# Altium schematic sheet Sheet3.SchDoc(Sheet3)
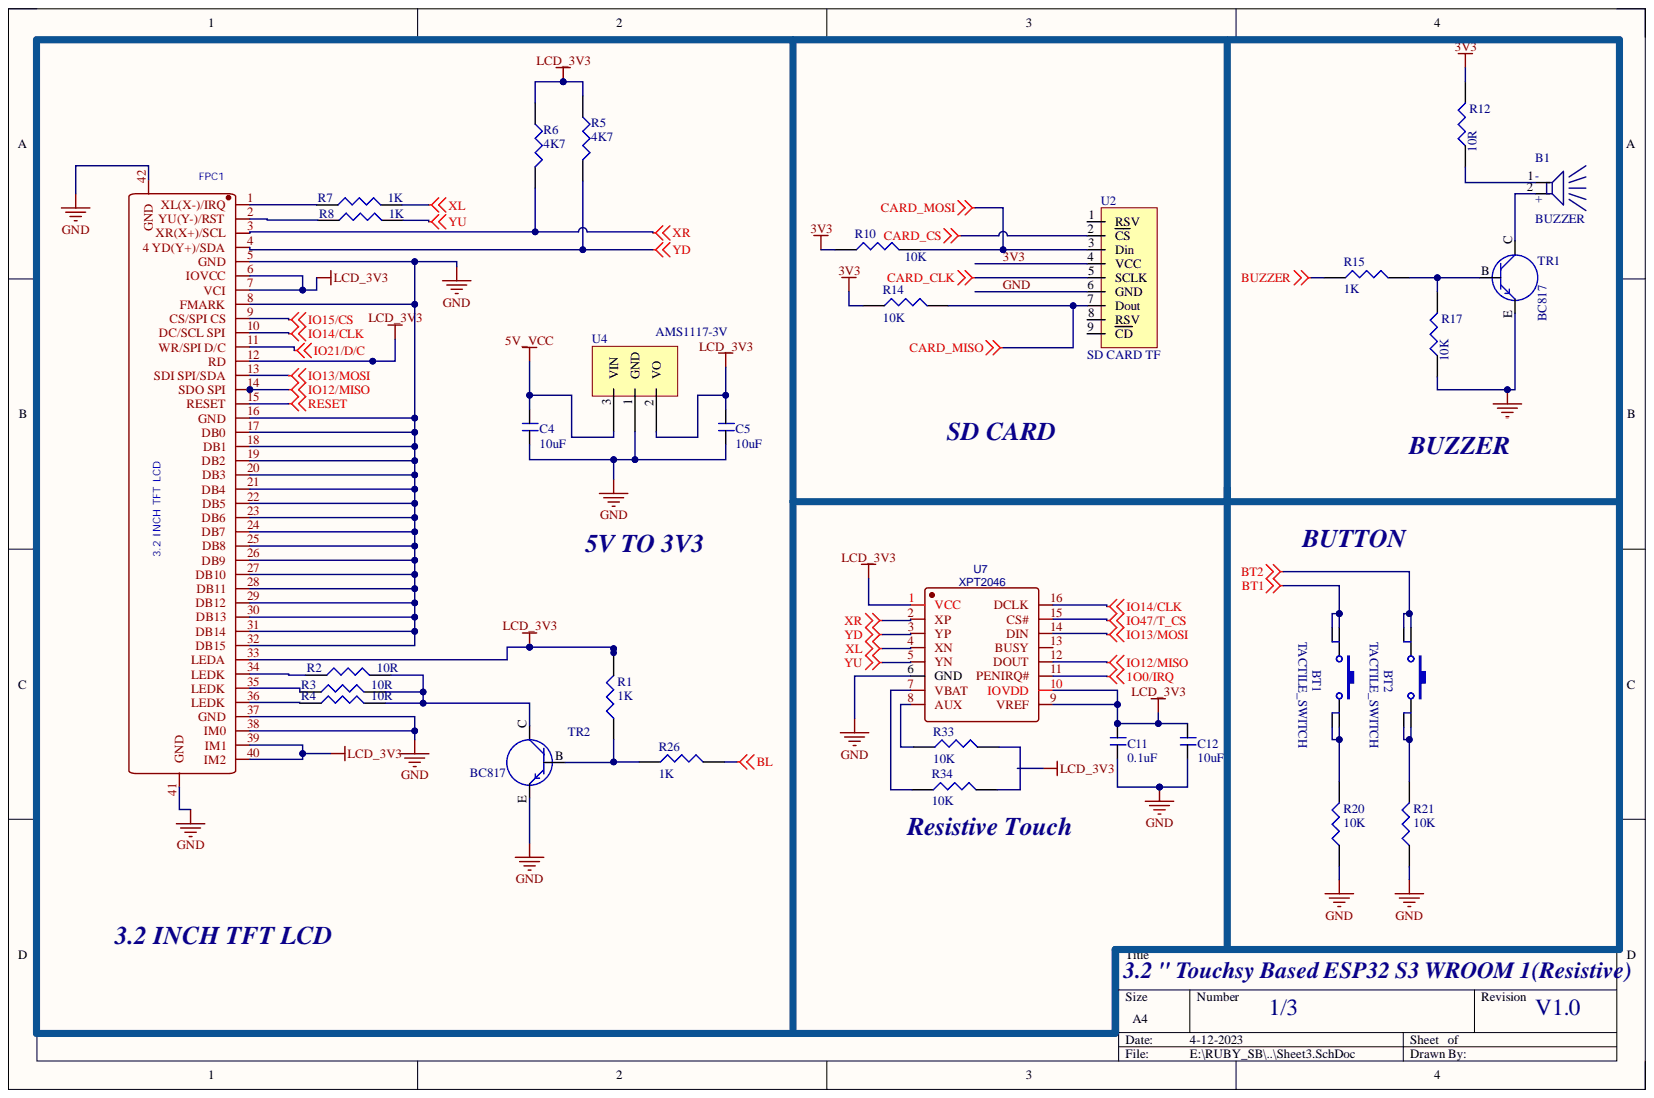
[diagram p0: Sheet3.SchDoc]

## Parts
B1  BUZZER  [SMD BUZZ]
BT1  TACTILE_SWITCH  [TACTILE]
BT2  TACTILE_SWITCH  [TACTILE]
C4  10uF  [C_0603]
C5  10uF  [C_0603]
C11  0.1uF  [C_0603]
C12  10uF  [C_0603]
FPC1  3.2 INCH TFT LCD  [3.2 TFT]
R1  1K  [R_0603]
R2  10R  [R_0603]
R3  10R  [R_0603]
R4  10R  [R_0603]
R5  4K7  [R_0603]
R6  4K7  [R_0603]
R7  1K  [R_0603]
R8  1K  [R_0603]
R10  10K  [R_0603]
R12  10R  [R_0603]
R14  10K  [R_0603]
R15  1K  [R_0603]
R17  10K  [R_0603]
R20  10K  [R_0603]
R21  10K  [R_0603]
R26  1K  [R_0603]
R33  10K  [R_0603]
R34  10K  [R_0603]
TR1  BC817  [SOT23]
TR2  BC817  [SOT23]
U2  SD CARD TF  [micro sd card]
U4  AMS1117-3V  [AMS1117_3V3]
U7  XPT2046  [TSSOP-16]

## Nets
1O0/IRQ: U7.11(PENIRQ#)
5V_VCC: C4.2 U4.3
BL: R26.1
BT1: BT1.1 BT1.2
BT2: BT2.1 BT2.2
BUZZER: R15.1
CARD_CLK: U2.5(SCLK)
CARD_CS: U2.2(CS)
CARD_MISO: R14.2 U2.7(Dout)
CARD_MOSI: R10.2 U2.3(Din)
IO12/MISO: FPC1.14(SDO_SPI) U7.12(DOUT)
IO13/MOSI: FPC1.13(SDI_SPI/SDA) U7.14(DIN)
IO14/CLK: FPC1.10(DC/SCL_SPI) U7.16(DCLK)
IO15/CS: FPC1.9(CS/SPI_CS)
IO21/D/C: FPC1.11(WR/SPI_D/C)
IO47/T_CS: U7.15(CS#)
LCD_3V3: C5.2 C11.2 C12.2 FPC1.6(IOVCC) FPC1.7(VCI) FPC1.12(RD) FPC1.33(LEDA) FPC1.39(IM1) FPC1.40(IM2) R1.1 R5.1 R6.1 U4.2 U7.1(VCC) U7.9(VREF) U7.10(IOVDD)
RESET: FPC1.15(RESET)
XL: R7.2 U7.4(XN)
XR: FPC1.3(XR(X+)/SCL) R6.2 U7.2(XP)
YD: FPC1.4(4_YD(Y+)/SDA) R5.2 U7.3(YP)
YU: R8.2 U7.5(YN)
3V3: R10.1 R12.2 R14.1 U2.4(VCC)
GND: C4.1 C5.1 C11.1 C12.1 FPC1.5(GND) FPC1.8(FMARK) FPC1.16(GND) FPC1.17(DB0) FPC1.18(DB1) FPC1.19(DB2) FPC1.20(DB3) FPC1.21(DB4) FPC1.22(DB5) FPC1.23(DB6) FPC1.24(DB7) FPC1.25(DB8) FPC1.26(DB9) FPC1.27(DB10) FPC1.28(DB11) FPC1.29(DB12) FPC1.30(DB13) FPC1.31(DB14) FPC1.32(DB15) FPC1.37(GND) FPC1.38(IM0) FPC1.41(GND) FPC1.42(GND) R17.1 R20.1 R21.1 TR1.E TR2.E U2.6(GND) U4.1 U7.6(GND)
NetB1_1: B1.1 R12.1
NetB1_2: B1.2 TR1.C
NetBT1_3: BT1.3 BT1.4 R20.2
NetBT2_3: BT2.3 BT2.4 R21.2
NetFPC1_1: FPC1.1(XL(X-)/IRQ) R7.1
NetFPC1_2: FPC1.2(YU(Y-)/RST) R8.1
NetFPC1_34: FPC1.34(LEDK) R2.1
NetFPC1_35: FPC1.35(LEDK) R3.1
NetFPC1_36: FPC1.36(LEDK) R4.1
NetR1_2: R1.2 R26.2 TR2.B
NetR2_2: R2.2 R3.2 R4.2 TR2.C
NetR15_2: R15.2 R17.2 TR1.B
NetR33_1: R33.1 R34.1
NetR33_2: R33.2 U7.8(AUX)
NetR34_2: R34.2 U7.7(VBAT)

Not connected: U2 1(RSV) 8(RSV) 9(CD); U7 13(BUSY)

## Library data of the parts
B1 = BUZZER PIEZO: Component Kind: Standard; Library Name: dxp_pasl_lib.IntLib
C4 C5 C11 C12 = CAP_NONPOLAR: Component Kind: Standard; Library Name: dxp_pasl_lib.IntLib
FPC1 = FPC-40P: Component Kind: Standard; Library Name: MIX.SchLib
R1-R8 R10 R12 R14 R15 R17 R20 R21 R26 R33 R34 = RES: Component Kind: Standard; Library Name: dxp_pasl_lib.IntLib
TR1 TR2 = TRANSISTER_NPN: Component Kind: Standard; Library Name: dxp_pasl_lib.IntLib
U2 = SD CARD TF: Micro SD Memory Card Socket; Component Kind: Standard; Library Name: dxp_pasl_lib.IntLib
U4 = AMS1117: Component Kind: Standard; Library Name: dxp_pasl_lib.IntLib
U7 = XPT2046: BOM_Manufacturer: XPT(矽普特); BOM_JLCPCB Part Class: Extended Part; BOM_Manufacturer Part: XPT2046; BOM_Supplier: LCSC; BOM_Supplier Part: C19076; Component Kind: Standard; spicePre: U; spiceSymbolName: XPT2046
BT1 BT2 = TACTILE_SWITCH: Component Kind: Standard; Library Name: dxp_pasl_lib.IntLib
